# Altium schematic sheet PowerSupply.SchDoc
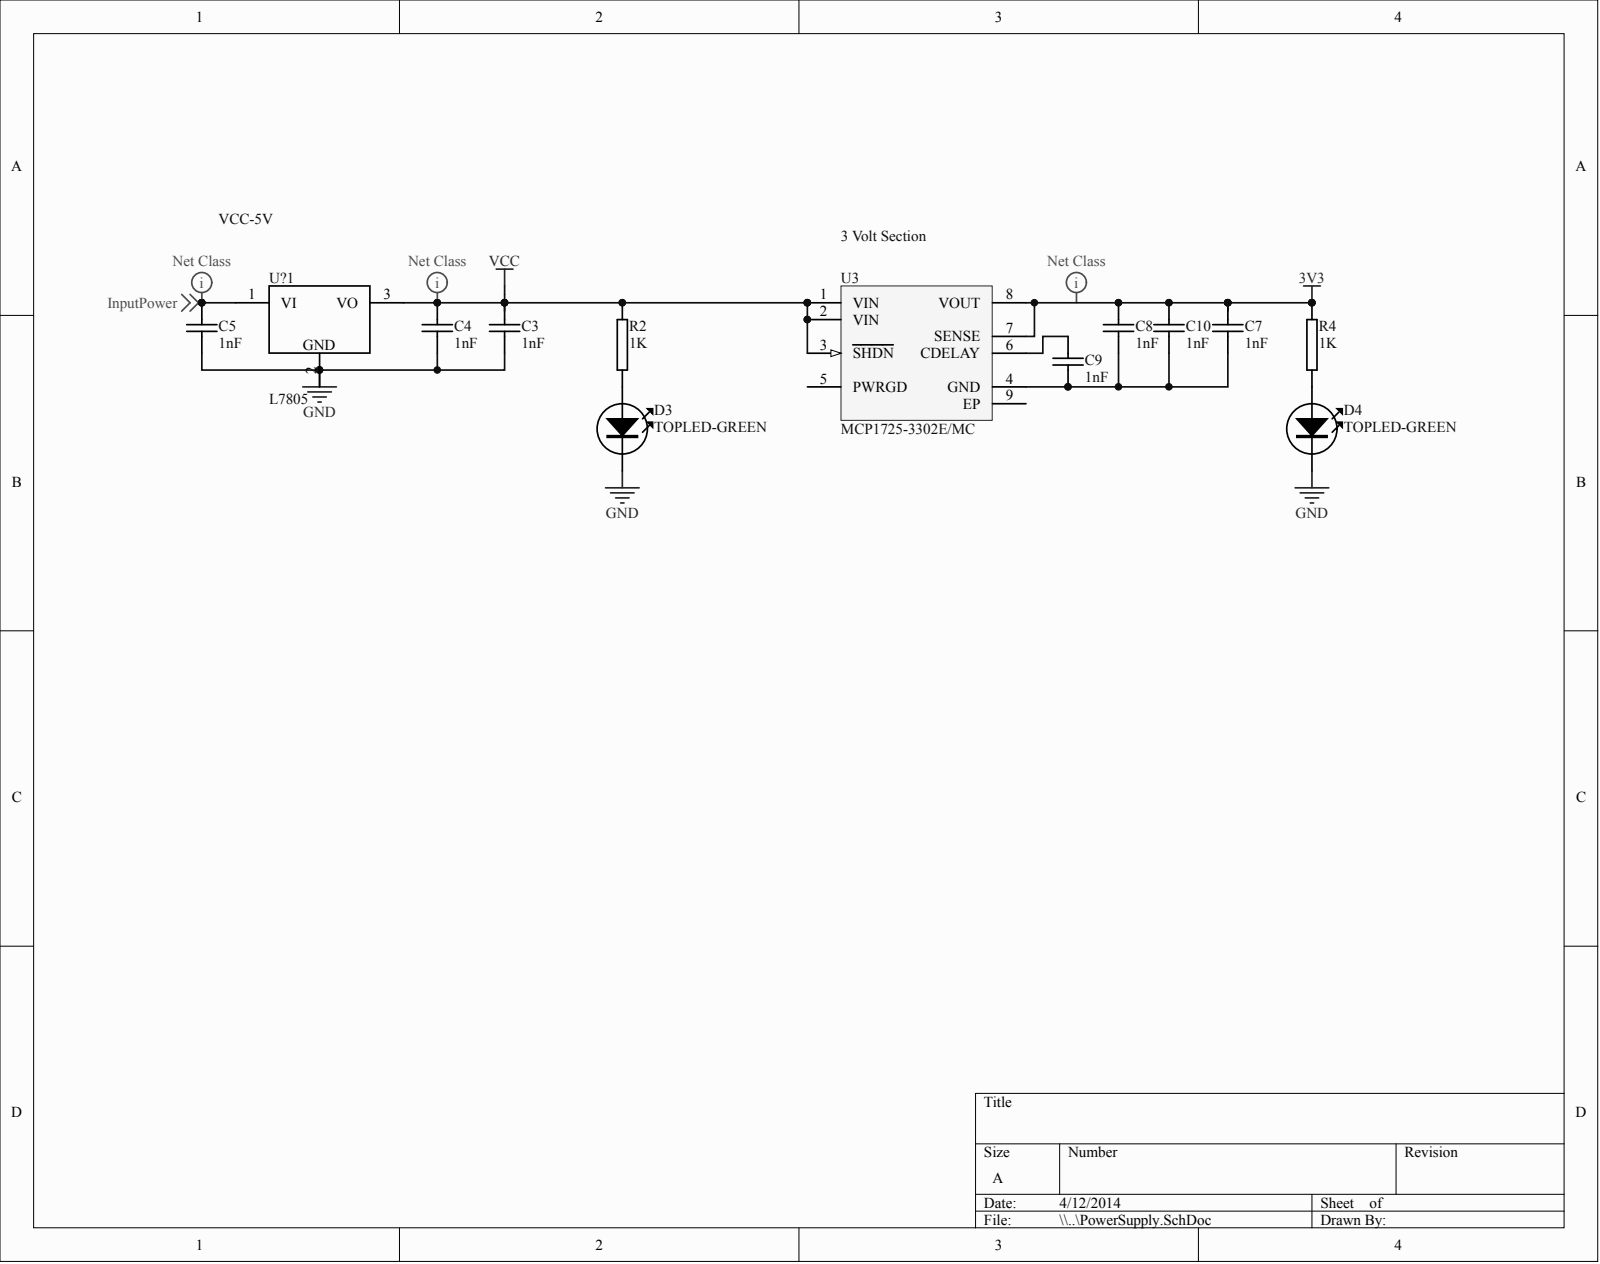
[diagram p0: PowerSupply.SchDoc]

## Parts
C3  1nF
C4  1nF
C5  1nF
C7  1nF
C8  1nF
C9  1nF
C10  1nF
D3  TOPLED-GREEN
D4  TOPLED-GREEN
R2  1K
R4  1K
U3  MCP1725-3302E/MC
U?1  L7805

## Nets
3V3: C7.1 C8.1 C10.1 R4.1 U3.7(SENSE) U3.8(VOUT)
GND: C3.2 C4.2 C5.2 D3.K D4.K
INPUTPOWER: C5.1 U?1.1
VCC: C3.1 C4.1 R2.1 U3.1(VIN) U3.2(VIN) U3.3(SHDN) U?1.3
NetC7_2: C7.2 C8.2 C9.2 C10.2 U3.4(GND)
NetC9_1: C9.1 U3.6(CDELAY)
NetD3_A: D3.A R2.2
NetD4_A: D4.A R4.2

Not connected: U3 5(PWRGD) 9(EP); U?1 2

## Library data of the parts
R2 R4 = (no symbol name): Manufacturer Part Number: RMCF 1/16 1K 1% R; Manufacturer: Stackpole Electronics Inc; Reel: R14; Supplier 1: Digi-Key; Supplier Part Number 1: RMCF0603FT1K00TR-ND
C3-C5 C7-C10 D3 D4 U3 U?1 = (no symbol name)
  U3: Published: 29-Jan-2009; DatasheetVersion: revB, Dec-2007; PackageVersion: revBB, Aug-2009; PackageReference: DFN-MC8; PackageDescription: 8-Lead Plastic Dual Flat, No Lead Package (MC) - 2x3x0.9mm Body [DFN]; Supplier 1: Digi-Key; Supplier Part Number 1: MCP1725-3302E/MC-ND; ComponentLink1Description: Datasheet; ComponentLink1URL: http://ww1.microchip.com/downloads/en/DeviceDoc/22026b.pdf; ComponentLink2Description: Packaging Specification; ComponentLink2URL: http://www.microchip.com/stellent/groups/techpub_sg/documents/packagingspec/en012702.pdf; ComponentLink3Description: Microchip.com Home; ComponentLink3URL: http://www.microchip.com/; Publisher: Altium Limited
  U?1: Supplier 1: Digi-Key; Supplier Part Number 1: 497-1170-6-ND
